# Altium schematic sheet lps_regulator_3.SchDoc
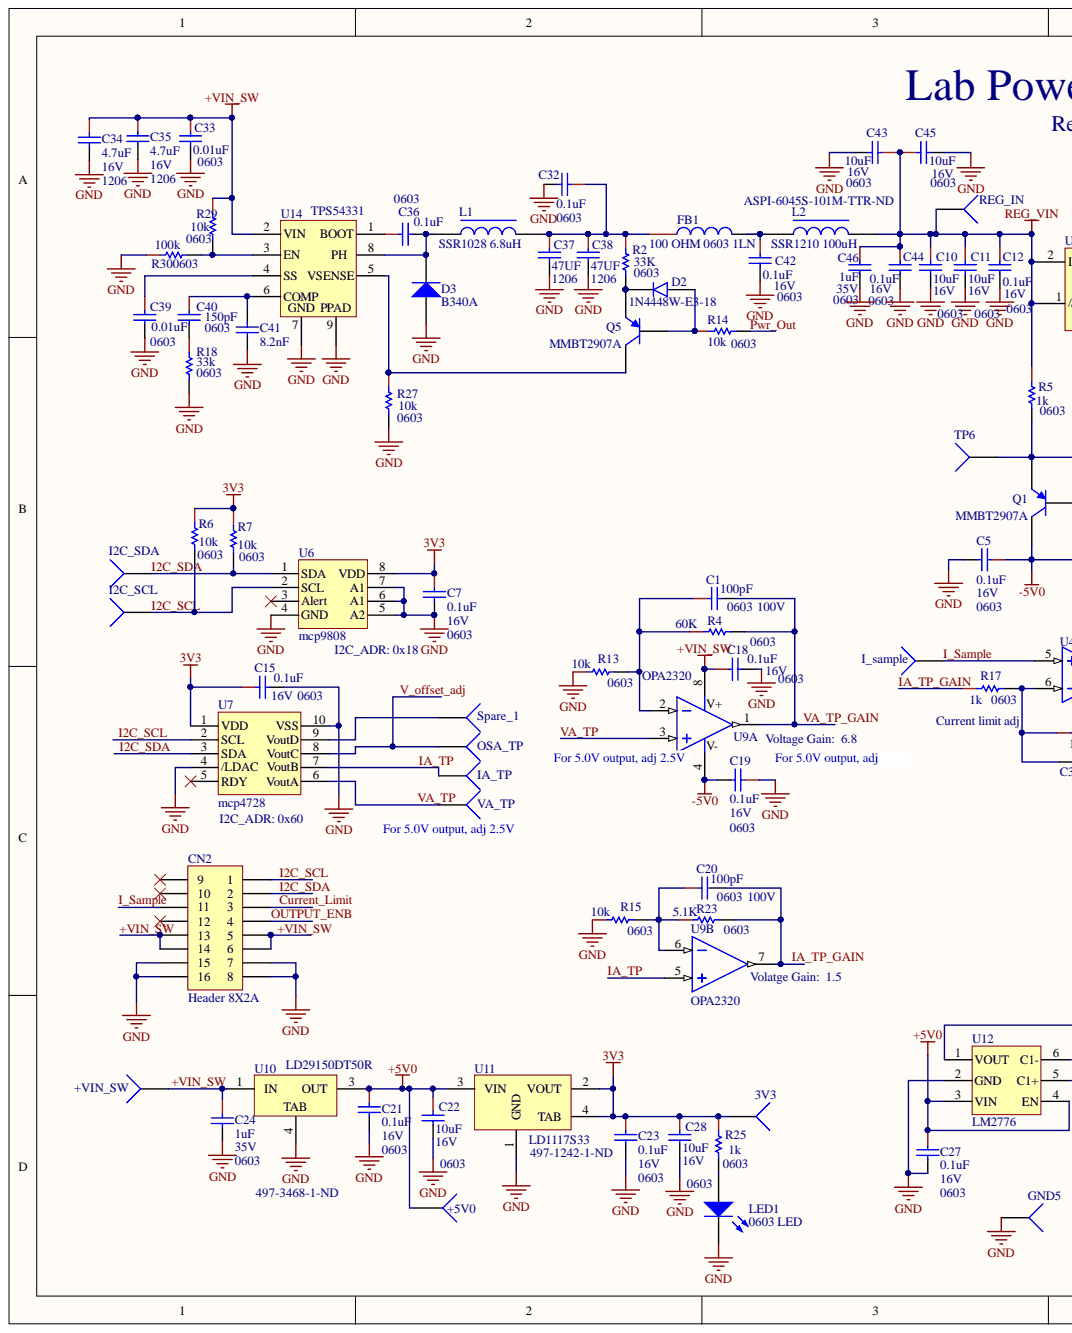
[diagram p0: lps_regulator_3.SchDoc, left]
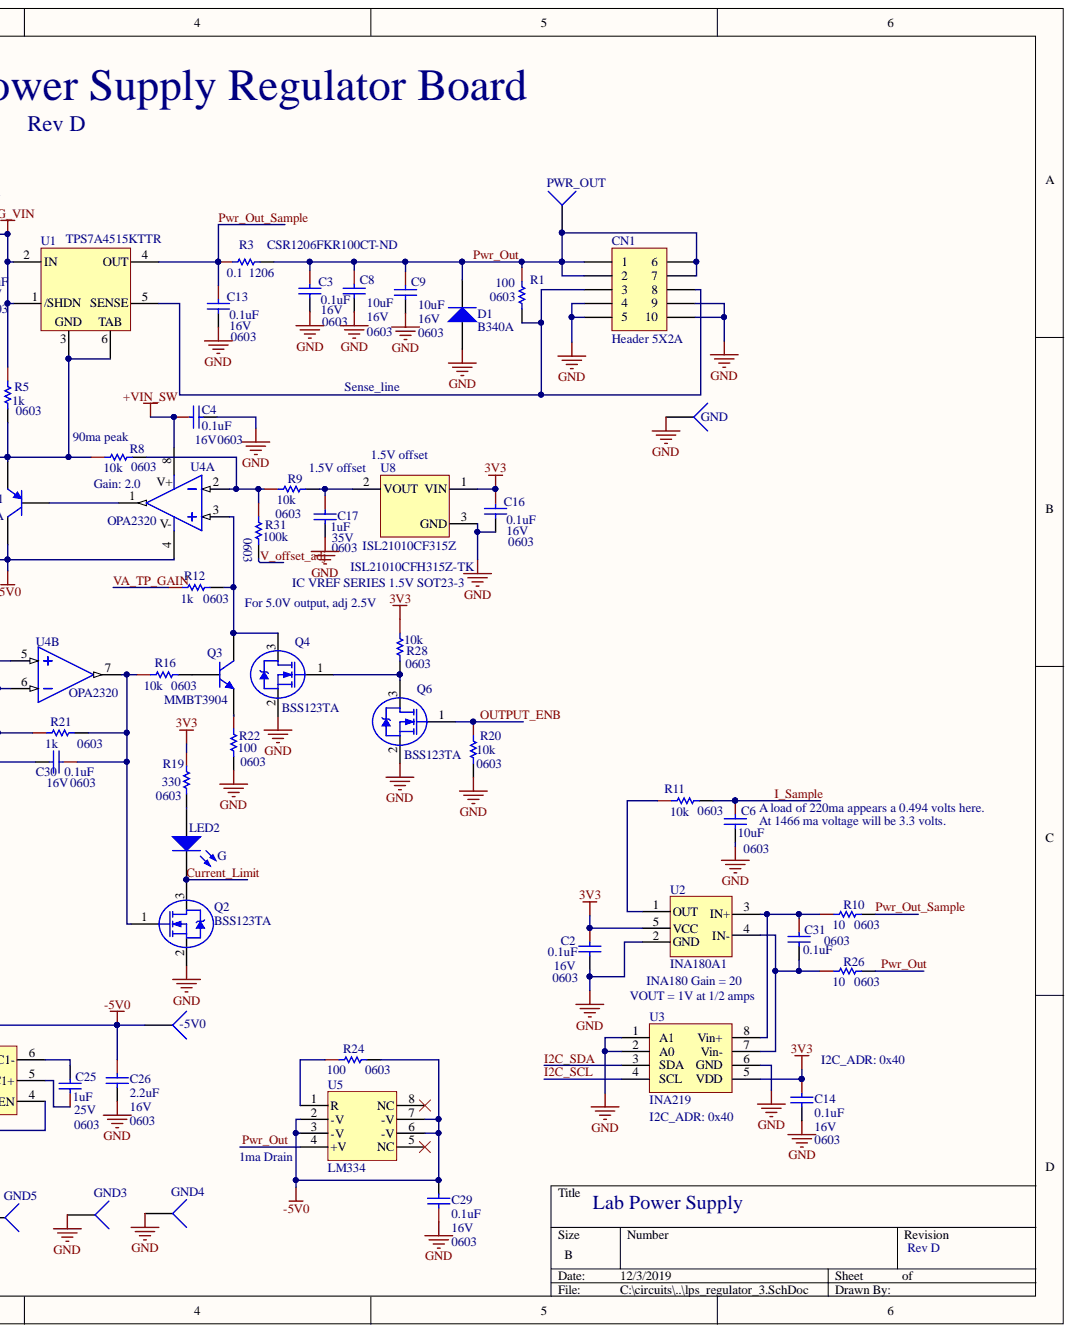
[diagram p1: lps_regulator_3.SchDoc, right]

## Parts
3V3  TestPoint_BLK  [TestPoint-TH 42 mil]  (value not drawn)
+5V0  TestPoint_BLK  [TestPoint-TH 42 mil]  (value not drawn)
+VIN_SW  TestPoint_BLK  [TestPoint-TH 42 mil]  (value not drawn)
-5V0  TestPoint_BLK  [TestPoint-TH 42 mil]  (value not drawn)
C1  100pF  Package (Imperial): 0603  Voltage (Rated): 100V  [CAPC0603(1608)_N]
C2  0.1uF  Package (Imperial): 0603  Voltage (Rated): 16V  [CAPC0603(1608)_M]
C3  0.1uF  Package (Imperial): 0603  Voltage (Rated): 16V  [CAPC0603(1608)_N]
C4  0.1uF  [CAPC0603(1608)_N]
C5  0.1uF  Package (Imperial): 0603  Voltage (Rated): 16V  [CAPC0603(1608)_N]
C6  10uF  Package (Imperial): 0603  [CAPC0603(1608)_N]
C7  0.1uF  Package (Imperial): 0603  Voltage (Rated): 16V  [CAPC0603(1608)_M]
C8  10uF  Package (Imperial): 0603  Voltage (Rated): 16V  [CAPC0603(1608)_N]
C9  10uF  Package (Imperial): 0603  Voltage (Rated): 16V  [CAPC0603(1608)_N]
C10  10uF  Package (Imperial): 0603  Voltage (Rated): 16V  [CAPC0603(1608)_N]
C11  10uF  Package (Imperial): 0603  Voltage (Rated): 16V  [CAPC0603(1608)_N]
C12  0.1uF  Package (Imperial): 0603  Voltage (Rated): 16V  [CAPC0603(1608)_N]
C13  0.1uF  Package (Imperial): 0603  Voltage (Rated): 16V  [CAPC0603(1608)_M]
C14  0.1uF  Package (Imperial): 0603  Voltage (Rated): 16V  [CAPC0603(1608)_N]
C15  0.1uF  Package (Imperial): 0603  Voltage (Rated): 16V  [CAPC0603(1608)_N]
C16  0.1uF  Package (Imperial): 0603  Voltage (Rated): 16V  [CAPC0603(1608)_N]
C17  1uF  Package (Imperial): 0603  Voltage (Rated): 35V  [CAPC0603(1608)_M]
C18  0.1uF  Package (Imperial): 0603  Voltage (Rated): 16V  [CAPC0603(1608)_M]
C19  0.1uF  Package (Imperial): 0603  Voltage (Rated): 16V  [CAPC0603(1608)_M]
C20  100pF  Package (Imperial): 0603  Voltage (Rated): 100V  [CAPC0603(1608)_N]
C21  0.1uF  Package (Imperial): 0603  Voltage (Rated): 16V  [CAPC0603(1608)_M]
C22  10uF  Package (Imperial): 0603  Voltage (Rated): 16V  [CAPC0603(1608)_N]
C23  0.1uF  Package (Imperial): 0603  Voltage (Rated): 16V  [CAPC0603(1608)_M]
C24  1uF  Package (Imperial): 0603  Voltage (Rated): 35V  [CAPC0603(1608)_M]
C25  1uF  Package (Imperial): 0603  Voltage (Rated): 25V  [CAPC0603(1608)_M]
C26  2.2uF  Package (Imperial): 0603  Voltage (Rated): 16V  [CAPC0603(1608)_M]
C27  0.1uF  Package (Imperial): 0603  Voltage (Rated): 16V  [CAPC0603(1608)_M]
C28  10uF  Package (Imperial): 0603  Voltage (Rated): 16V  [CAPC0603(1608)_N]
C29  0.1uF  Package (Imperial): 0603  Voltage (Rated): 16V  [CAPC0603(1608)_M]
C30  0.1uF  Package (Imperial): 0603  Voltage (Rated): 16V  [CAPC0603(1608)_M]
C31  0.1uF  Package (Imperial): 0603  [CAPC0603(1608)_N]
C32  0.1uF  [CAPC0603(1608)_N]
C33  0.01uF  Package (Imperial): 0603  [CAPC0603(1608)_N]
C34  4.7uF  Package (Imperial): 1206  Voltage (Rated): 16V  [CAPC1206(3216)_N]
C35  4.7uF  Package (Imperial): 1206  Voltage (Rated): 16V  [CAPC1206(3216)_N]
C36  0.1uF  Package (Imperial): 0603  [CAPC0603(1608)_N]
C37  47UF  Package (Imperial): 1206  [CAPC1206(3216)_L]
C38  47UF  Package (Imperial): 1206  [CAPC1206(3216)_L]
C39  0.01uF  Package (Imperial): 0603  [CAPC0603(1608)_N]
C40  150pF  Package (Imperial): 0603  [CAPC0603(1608)_M]
C41  8.2nF  Case/Package: 0603  [CAPC1608X87X35ML15T15]
C42  0.1uF  Package (Imperial): 0603  Voltage (Rated): 16V  [CAPC0603(1608)_N]
C43  10uF  Package (Imperial): 0603  Voltage (Rated): 16V  [CAPC0603(1608)_N]
C44  0.1uF  Package (Imperial): 0603  Voltage (Rated): 16V  [CAPC0603(1608)_N]
C45  10uF  Voltage (Rated): 16V  [CAPC0603(1608)_N]
C46  1uF  Package (Imperial): 0603  Voltage (Rated): 35V  [CAPC0603(1608)_M]
CN1  Header 5X2A  [HDR2X5_CEN]
CN2  Header 8X2A  [HDR2X8_CEN]
D1  B340A  [DO-214AC]
D2  1N4448W-E3-18  [SOD123]
D3  B340A  [DO-214AC]
FB1  100 OHM 0603 1LN  PkgCase: 0603  [0603-SMT]
GND  TestPoint_BLK  [TestPoint-TH 42 mil]  (value not drawn)
GND3  TestPoint_BLK  [TestPoint-TH 42 mil]  (value not drawn)
GND4  TestPoint_BLK  [TestPoint-TH 42 mil]  (value not drawn)
GND5  TestPoint_BLK  [TestPoint-TH 42 mil]  (value not drawn)
I2C_SCL  TestPoint_BLK  [TestPoint-TH 42 mil]  (value not drawn)
I2C_SDA  TestPoint_BLK  [TestPoint-TH 42 mil]  (value not drawn)
I_sample  TestPoint_BLK  [TestPoint-TH 42 mil]  (value not drawn)
IA_TP  TestPoint_BLK  [TestPoint-TH 42 mil]  (value not drawn)
L1  SSR1028 6.8uH  [SRU1028]
L2  SSR1210 100uH  [SRR1210]
LED1  0603 LED  [SM-LED-0603]
LED2  G  [SM-LED-0603]
OSA_TP  TestPoint_BLK  [TestPoint-TH 42 mil]  (value not drawn)
PWR_OUT  TestPoint_BLK  [TestPoint-TH 42 mil]  (value not drawn)
Q1  MMBT2907A  [SOT23]
Q2  BSS123TA  [SOT23-3L]
Q3  MMBT3904  [SOT23]
Q4  BSS123TA  [SOT23-3L]
Q5  MMBT2907A  [SOT23]
Q6  BSS123TA  [SOT23-3L]
R1  100  Package (Imperial): 0603  [RESC0603(1608)_N]
R2  Resistance: 33K  [RESC0603(1608)_M]  (hidden comment: 10K)
R3  Package (Imperial): 1206  Resistance: 0.1  [RESC1206(3216)_N]  (hidden comment: 0.33)
R4  100  Package (Imperial): 0603  Resistance: 60K  [RESC0603(1608)_N]
R5  Package (Imperial): 0603  Resistance: 1k  [RESC0603(1608)_M]  (hidden comment: 10K)
R6  Package (Imperial): 0603  [RESC0603(1608)_M]  (hidden comment: 10K)
R7  Package (Imperial): 0603  [RESC0603(1608)_M]  (hidden comment: 10K)
R8  Package (Imperial): 0603  [RESC0603(1608)_M]  (hidden comment: 10K)
R9  10K  [RESC0603(1608)_M]
R10  Package (Imperial): 0603  [RESC0603(1608)_M]  (hidden comment: 10K)
R11  Package (Imperial): 0603  [RESC0603(1608)_M]  (hidden comment: 10K)
R12  Package (Imperial): 0603  Resistance: 1k  [RESC0603(1608)_M]  (hidden comment: 1.0K)
R13  Package (Imperial): 0603  Resistance: 10k  [RESC0603(1608)_M]  (hidden comment: 1.0K)
R14  Package (Imperial): 0603  [RESC0603(1608)_M]  (hidden comment: 10K)
R15  Package (Imperial): 0603  Resistance: 10k  [RESC0603(1608)_M]  (hidden comment: 1.0K)
R16  Package (Imperial): 0603  Resistance: 10k  [RESC0603(1608)_M]  (hidden comment: 1.0K)
R17  Package (Imperial): 0603  Resistance: 1k  [RESC0603(1608)_M]  (hidden comment: 1.0K)
R18  Package (Imperial): 0603  [RESC0603(1608)_N]  (hidden comment: 33K)
R19  Package (Imperial): 0603  [RESC0603(1608)_M]  (hidden comment: 1.0K)
R20  Package (Imperial): 0603  [RESC0603(1608)_M]  (hidden comment: 10K)
R21  Package (Imperial): 0603  Resistance: 1k  [RESC0603(1608)_M]  (hidden comment: 100K)
R22  Package (Imperial): 0603  [RESC0603(1608)_M]  (hidden comment: 1.0K)
R23  100  Package (Imperial): 0603  [RESC0603(1608)_N]
R24  100  Package (Imperial): 0603  [RESC0603(1608)_N]
R25  Package (Imperial): 0603  Resistance: 1k  [RESC0603(1608)_M]  (hidden comment: 1.0K)
R26  Package (Imperial): 0603  [RESC0603(1608)_M]  (hidden comment: 10K)
R27  Package (Imperial): 0603  [RESC0603(1608)_M]  (hidden comment: 10K)
R28  Package (Imperial): 0603  [RESC0603(1608)_M]  (hidden comment: 10K)
R29  Package (Imperial): 0603  [RESC0603(1608)_M]  (hidden comment: 10K)
R30  Package (Imperial): 0603  Resistance: 100k  [RESC0603(1608)_M]  (hidden comment: 10K)
R31  Package (Imperial): 0603  Resistance: 100k  [RESC0603(1608)_M]  (hidden comment: 1.0K)
REG_IN  TestPoint_BLK  [TestPoint-TH 42 mil]  (value not drawn)
Spare_1  TestPoint_BLK  [TestPoint-TH 42 mil]  (value not drawn)
TP6  TestPoint_BLK  [TestPoint-TH 42 mil]  (value not drawn)
U1  TPS7A4515KTTR  [TPS7A4515KTTR]
U2  INA180A1  [SOT-23-5_MC]
U3  INA219  [INA219]
U4  OPA2320  [SOIC-8_NoPad]  (parts A,B)
U5  LM334  [LM334]
U6  mcp9808  [8MSOP]
U7  mcp4728  [MCP4728]
U8  ISL21010CF315Z  [SOT23]
U9  OPA2320  [SOIC-8_NoPad]  (parts A,B)
U10  LD29150DT50R  [TO-252_N]
U11  LD1117S33  [DSO-G3]
U12  LM2776  [SOt-23-6]
U14  TPS54331  [TPS54331]
VA_TP  TestPoint_BLK  [TestPoint-TH 42 mil]  (value not drawn)

## Nets
3V3: 3V3.1 C2.1 C7.1 C14.1 C15.1 C16.1 C23.1 C28.1 R6.1 R7.1 R19.1 R25.1 R28.1 U2.5(VCC) U3.5(VDD) U6.8(VDD) U7.1(VDD) U8.1 U11.2(VOUT) U11.4(TAB)
+5V0: +5V0.1 C21.1 C22.1 C27.1 U10.3 U11.3(VIN) U12.3(VIN) U12.4(EN)
-5V0: -5V0.1 C5.1 C19.2 C26.1 C29.2 Q1.3 R24.2 U4.4 U5.2(-V) U5.3(-V) U5.6(-V) U5.7(-V) U9.4 U12.1(VOUT)
GND: C2.2 C3.2 C4.2 C5.2 C6.2 C7.2 C8.2 C9.2 C10.2 C11.2 C12.2 C13.2 C14.2 C15.2 C16.2 C17.2 C18.2 C19.1 C21.2 C22.2 C23.2 C24.2 C26.2 C27.2 C28.2 C29.1 C32.2 C33.2 C34.2 C35.2 C37.2 C38.2 C39.2 C41.1 C42.2 C43.2 C44.2 C45.2 C46.2 CN1.4 CN1.5 CN1.9 CN1.10 CN2.7 CN2.8 CN2.15 CN2.16 D1.1 D3.1 GND.1 GND3.1 GND4.1 GND5.1 LED1.2 Q2.2 Q4.2 Q6.2 R13.1 R15.1 R18.2 R20.2 R22.2 R27.2 R30.1 U2.2(GND) U3.1(A1) U3.2(A0) U3.6(GND) U6.4(GND) U6.5(A2) U6.6(A1) U6.7(A1) U7.4(/LDAC) U7.10(VSS) U8.3 U10.4 U11.1(GND) U12.2(GND) U14.7 U14.9
REG_VIN: C10.1 C11.1 C12.1 C43.1 C44.1 C45.1 C46.1 L2.2 R5.1 REG_IN.1 U1.1(/SHDN) U1.2(IN)
+VIN_SW: +VIN_SW.1 C4.1 C18.1 C24.1 C33.1 C34.1 C35.1 CN2.5 CN2.6 CN2.13 CN2.14 R29.1 U4.8 U9.8 U10.1 U14.2(VIN)
Current_Limit: CN2.3 LED2.2 Q2.3
I2C_SCL: CN2.1 I2C_SCL.1 R6.2 U3.4(SCL) U6.2(SCL) U7.2(SCL)
I2C_SDA: CN2.2 I2C_SDA.1 R7.2 U3.3(SDA) U6.1(SDA) U7.3(SDA)
I_Sample: C6.1 CN2.11 I_sample.1 R11.2 U4.5
IA_TP: IA_TP.1 U7.7(VoutB) U9.5
IA_TP_GAIN: C20.2 R17.1 R23.2 U9.7
OUTPUT_ENB: CN2.4 Q6.1 R20.1
Pwr_Out: C3.1 C8.1 C9.1 CN1.1 CN1.2 CN1.6 CN1.7 D1.2 PWR_OUT.1 R1.1 R3.2 R14.2 R26.2 U5.4(+V)
Pwr_Out_Sample: C13.1 R3.1 R10.2 U1.4(OUT)
V_offset_adj: OSA_TP.1 R31.2 U7.8(VoutC)
VA_TP: U7.6(VoutA) U9.3 VA_TP.1
VA_TP_GAIN: C1.2 R4.2 R12.1 U9.1
NetC1_1: C1.1 R4.1 R13.2 U9.2
NetC17_1: C17.1 R9.2 U8.2
NetC20_1: C20.1 R15.2 R23.1 U9.6
NetC25_1: C25.1 U12.5(C1+)
NetC25_2: C25.2 U12.6(C1-)
NetC30_1: C30.1 Q2.1 R16.1 R21.2 U4.7
NetC30_2: C30.2 R17.2 R21.1 U4.6
NetC31_1: C31.1 R10.1 U2.3(IN+) U3.8(Vin+)
NetC31_2: C31.2 R26.1 U2.4(IN-) U3.7(Vin-)
NetC32_1: C32.1 C37.1 C38.1 FB1.1 L1.2 R2.1
NetC36_1: C36.1 U14.1(BOOT)
NetC36_2: C36.2 D3.2 L1.1 U14.8(PH)
NetC39_1: C39.1 U14.4(SS)
NetC40_1: C40.1 C41.2 U14.6(COMP)
NetC40_2: C40.2 R18.1
NetC42_1: C42.1 FB1.2 L2.1
NetCN1_3: CN1.3 CN1.8 R1.2 U1.5(SENSE)
NetD2_1: D2.1 Q5.1 R14.1
NetD2_2: D2.2 Q5.2 R2.2
NetLED1_1: LED1.1 R25.2
NetLED2_1: LED2.1 R19.2
NetQ1_1: Q1.1 U4.1
NetQ1_2: Q1.2 R5.2 R8.1 TP6.1 U1.3 U1.6
NetQ3_1: Q3.1 R16.2
NetQ3_2: Q3.2 R22.1
NetQ3_3: Q3.3 Q4.3 R12.2 U4.3
NetQ4_1: Q4.1 Q6.3 R28.2
NetQ5_3: Q5.3 R27.1 U14.5(VSENSE)
NetR8_2: R8.2 R9.1 R31.1 U4.2
NetR11_1: R11.1 U2.1(OUT)
NetR24_1: R24.1 U5.1(R)
NetR29_2: R29.2 R30.2 U14.3(EN)
NetSpare_1_1: Spare_1.1 U7.9(VoutD)

Not connected: CN2 9 10 12; U5 5(NC) 8(NC); U6 3(Alert); U7 5(RDY)

## Library data of the parts
3V3 +5V0 +VIN_SW -5V0 GND GND3-GND5 I2C_SCL I2C_SDA I_sample IA_TP OSA_TP PWR_OUT REG_IN Spare_1 TP6 VA_TP = CMP-005-0210-01: Test Point - Black; Manufacturer: Keystone Electronics; Manufacturer Part Number: 5001; Color: Black; Component Kind: Standard; ComponentLink1Description: http://www.keyelco.com/product-pdf.cfm?p=1310; ComponentLink1URL: http://www.keyelco.com/product-pdf.cfm?p=1310; Component Name: TestPoint_BLK; Designator: TestPoint_BLK; Material: Base, Nylon 46, UL 94V-0; Mounting Type: 0.040" (1.02mm) Hole Diameter; Packaging: Bulk; Pin Count: 1; Pricing 1: 1=0.37, 10=0.316, 50=0.2298, 100=0.2209, 250=0.19832, 500=0.18932, 1000=0.1616, 2500=0.14424, 5000=0.13523 (USD); RoHS: RoHS Compliant; Stock 1: 335679; Terminal: 0.010" x 0.020" (0.25mm x 0.51mm) Phosphor Bronze Wire, Silver Plated; Type: PC Test Point, Miniature; Library Name: OLogic Nexus Vault
C1 C20 = CMP-007-0074-08: CAP CER 100PF 50V 5% NP0 0603; Dielectric Material: Ceramic; Package (Metric): 1608; Temperature Coefficient: C0G, NP0; Capacitance: 100pF; Component Kind: Standard; Mounting Type: SMD; Tolerance: 5%; Library Name: OLogic Nexus Vault
C2 C3 C5 C7 C12-C16 C18 C19 C21 C23 C27 C29-C31 C36 C42 C44 = CMP-007-0042-08: CAP CER 0.1UF 16V 10% X7R 0603; Capacitance: 100nF; Dielectric Material: Ceramic; Package (Metric): 1608; Component Kind: Standard; Mounting Type: SMD; Temperature Coefficient: X7R; Tolerance: 10%; Library Name: OLogic Nexus Vault
  C31 C36: Voltage (Rated): 16V
C4 C32 = CMP-007-0042-08: CAP CER 0.1UF 16V 10% X7R 0603; Capacitance: 100nF; Dielectric Material: Ceramic; Package (Imperial): 0603; Package (Metric): 1608; Component Kind: Standard; Mounting Type: SMD; Temperature Coefficient: X7R; Tolerance: 10%; Voltage (Rated): 16V; Library Name: OLogic Nexus Vault
C6 C8-C11 C22 C28 C43 = CMP-001-0603-12: CAP CER 10UF 16V X5R 0603; Capacitance: 10µF; Dielectric Material: Ceramic; Package (Metric): 1005; Temperature (Max): 85°C; Temperature (Min): -55°C; Tolerance: 10%; Component Kind: Standard; Height: 800µm; Mounting Type: SMD; Temperature Coefficient: X5R; Width: 800µm; Library Name: OLogic Nexus Vault
  C6: Voltage (Rated): 16V
C17 C24 C40 C46 = CMP-007-0109-08: CAP CER 1UF 35V 10% X5R 0603; Capacitance: 1µF; Dielectric Material: Ceramic; Package (Metric): 1608; Temperature (Max): 85°C; Temperature (Min): -55°C; Component Kind: Standard; Height: 900µm; Length: 1.6mm; Mounting Type: SMD; Temperature Coefficient: X5R; Tolerance: 10%; Width: 800µm; Library Name: OLogic Nexus Vault
  C40: Voltage (Rated): 35V
C25 = CMP-007-0108-08: CAP CER 1UF 25V 10% X7R 0603; Capacitance: 1µF; Dielectric Material: Ceramic; Package (Metric): 1608; Temperature (Max): 125°C; Temperature (Min): -55°C; Component Kind: Standard; Height: 900µm; Length: 1.6mm; Mounting Type: SMD; Temperature Coefficient: X7R; Tolerance: 10%; Width: 800µm; Library Name: OLogic Nexus Vault
C26 = CMP-007-0114-08: CAP CER 2.2UF 16V 10% X5R 0603; Capacitance: 2.2µF; Dielectric Material: Ceramic; Package (Metric): 1608; Component Kind: Standard; Mounting Type: SMD; Temperature Coefficient: X5R; Tolerance: 10%; Library Name: OLogic Nexus Vault
C33 = CMP-007-0028-08: CAP CER 0.01uF 50V 10% X7R 0603; Capacitance: 10nF; Dielectric Material: Ceramic; Package (Metric): 1608; Temperature (Max): 125°C; Temperature (Min): -55°C; Component Kind: Standard; Height: 900µm; Length: 1.6mm; Mounting Type: SMD; Temperature Coefficient: X7R; Tolerance: 10%; Voltage (Rated): 50V; Width: 800µm; Library Name: OLogic Nexus Vault
C34 C35 = CMP-007-0147-08: CAP CER 4.7UF 50V 10% X7R 1206; Capacitance: 4.7µF; Dielectric Material: Ceramic; Package (Metric): 3216; Component Kind: Standard; Mounting Type: SMD; Temperature Coefficient: X7R; Tolerance: 10%; Library Name: OLogic Nexus Vault
C37 C38 = CMP-001-0623-11: CAP CER 47UF 25V 1206; Capacitance: 47µF; Dielectric Material: Ceramic; Package (Metric): 3216; Temperature (Max): 85°C; Temperature (Min): -55°C; Temperature Coefficient: X5R; Tolerance: 20%; Voltage (Rated): 100V; Component Kind: Standard; Height: 1.6mm; Length: 3.2mm; Mounting Type: SMD; Library Name: OLogic Nexus Vault
C39 = CMP-007-0128-08: CAP CER 22PF 50V 5% NP0 0603; Dielectric Material: Ceramic; Package (Metric): 1608; Temperature Coefficient: C0G, NP0; Capacitance: 22pF; Component Kind: Standard; Mounting Type: SMD; Tolerance: 5%; Voltage (Rated): 50V; Library Name: OLogic Nexus Vault
C41 = CMP-001-0751-01: CAP CER 820PF 50V X7R 0603; Dielectric Material: Ceramic; Dissipation Factor: 2.5%; Tolerance: 10%; Capacitance: 820pF; Case Code (Imperial): 0603; Case Code (Metric): 1608; Component Kind: Standard; Contact Plating: Matte, Tin; Depth: 0.8mm; Dielectric: X7R; Height: 0.8mm; Lead Pitch: 0.7mm; Length: 1.6mm; Max Operating Temperature: 125°C; Min Operating Temperature: -55°C; Mount: PCB, Surface Mount; Package Quantity: 1; Packaging: Tape and Reel; Radiation Hardening: No; REACH SVHC: No SVHC; Termination: SMD/SMT; Thickness: 0.034inch; Voltage: 50V; Voltage Rating: 50V; Voltage Rating (DC): 50V; Width: 0.8mm; Library Name: OLogic Nexus Vault
C45 = CMP-001-0603-12: CAP CER 10UF 16V X5R 0603; Capacitance: 10µF; Dielectric Material: Ceramic; Package (Imperial): 0603; Package (Metric): 1005; Temperature (Max): 85°C; Temperature (Min): -55°C; Tolerance: 10%; Component Kind: Standard; Height: 800µm; Mounting Type: SMD; Temperature Coefficient: X5R; Width: 800µm; Library Name: OLogic Nexus Vault
CN1 = CMP-001-0310-01: Header, 5-Pin, Dual row; Component Kind: Standard; Component Name: Header 5X2A; Designator: Header 5X2A; LatestRevisionDate: 17-Jul-2002; LatestRevisionNote: Re-released for DXP Platform.; Pin Count: 10; Signal Integrity: Connector; Library Name: OLogic Nexus Vault; Publisher: Altium Limited
CN2 = CMP-001-0325-01: Header, 8-Pin, Dual row; Component Kind: Standard; Component Name: Header 8X2A; Designator: Header 8X2A; LatestRevisionDate: 17-Jul-2002; LatestRevisionNote: Re-released for DXP Platform.; Pin Count: 16; Signal Integrity: Connector; Library Name: OLogic Nexus Vault; Publisher: Altium Limited
D1 D3 = CMP-001-0130-02: DIODE SCHOTTKY 60V 3A; Manufacturer: Micro Commercial Co; Capacitance @ Vr, F: 250pF @ 4V, 1MHz; Component Name: SK36A-LTP; Current - Reverse Leakage @ Vr: 500µA @ 60V; Speed: Fast Recovery =< 500ns, > 200mA (Io); Voltage - Forward (Vf) (Max) @ If: 750mV @ 3A; Component Kind: Standard; ComponentLink1Description: http://www.mccsemi.com/up_pdf/SK32A-LT~SK310A-LT(DO-214AC).PDF; ComponentLink1URL: http://www.mccsemi.com/up_pdf/SK32A-LT~SK310A-LT(DO-214AC).PDF; Current - Average Rectified (Io): 3A; Designator: SK36A-LTP; Diode Type: Schottky; Manufacturer Part Number: SK36A-LTP; Mounting Type: Surface Mount; Operating Temperature - Junction: -50°C ~ 125°C; Package / Case: DO-214AC, SMA; Packaging: Cut Tape (CT); Part Status: Active; Pin Count: 2; Pricing 1: 1=0.6, 10=0.42, 25=0.3448, 100=0.276, 250=0.20072, 500=0.1631, 1000=0.12545, 2500=0.11291 (USD); RoHS: RoHS Compliant; Stock 1: 26020; Supplier Device Package: DO-214AC (SMA); Library Name: OLogic Nexus Vault
D2 = CMP-001-0983-02: Diodes - General Purpose, Power, Switching 100 Volt 500mA 4ns; Average Rectified Current: 150mA; Element Configuration: Single; Max Power Dissipation: 500mW; Max Repetitive Reverse Voltage (Vrrm): 100V; Max Reverse Leakage Current: 5uA; Max Reverse Voltage (DC): 75V; Component Kind: Standard; Contact Plating: Tin; Forward Current: 150mA; Max Operating Temperature: 150°C; Max Surge Current: 500mA; Min Operating Temperature: -55°C; Mount: Surface Mount; Package Quantity: 10000; Packaging: Tape and Reel; Peak Non-Repetitive Surge Current: 500mA; Recovery Time: 4ns; Reverse Recovery Time: 4ns; Weight: 0.000363oz; Library Name: OLogic Nexus Vault
FB1 = CMP-026-0038-02: 100 Ohms @ 100MHz 1 Power Line Ferrite Bead 0603 (1608 Metric) 3A 30mOhm; ICSPartNumber: 600-0180; Manufacturer: TDK; Manufacturer Part Number: MPZ1608S101A; Component Name: FERRITE_BEAD_A; LifeStatus: CUR; MntType: SMT; OpTemp: -55C ~ 125C; value: 100R@100M; Component Kind: Standard; Current: 3A; DateCreated: 5/27/2013 5:21:44 PM; DateModified: 10/30/2014 12:43:40 AM; Designator: L; Features: 30 mOhm Max; HelpURL: http://www.tdk.co.jp/tefe02/e9413_mpz.pdf; ICS_PartType: ferrite; IPCCode: INDC1608X75-2; PartID: IND-000082; PartType: ferrite; Pin Count: 2; Revision: REV; RoHS: ROHS; Library Name: OLogic Nexus Vault
L1 = CMP-001-0686-01: INDUCTOR 6.8uH  SMD; Manufacturer 1: Taiyo Yuden; Manufacturer Part Number 1: NRS8040T4R7NJGJV; Core Material: Ferrite; Current Rating: 4.1A; DC Resistance (DCR): 18mR; Inductance: 4.7uh; Inductance Tolerance: 30%; Max DC Current: 5.4A; Self Resonant Frequency: 30MHz; Shielding: Shielded; Test Frequency: 100kHz; Case/Package: 8040; Component Kind: Standard; Depth: 8.2mm; Halogen Free: Halogen Free; Height: 4.2mm; Lead Free: Lead Free; Lead Pitch: 5.6mm; Length: 8mm; Max Operating Temperature: 125°C; Min Operating Temperature: -25°C; Mount: Surface Mount; Number of Pins: 2; Package Quantity: 1000; Packaging: Tape and Reel; Radiation Hardening: No; REACH SVHC: Unknown; RMS Current (Irms): 4.1A; Saturation Current: 5.4A; Termination: SMD/SMT; Tolerance: 30%; Width: 0.315inch; Library Name: OLogic Nexus Vault
L2 = CMP-001-0686-01: INDUCTOR 100uH 2.2A SMD; Manufacturer 1: Taiyo Yuden; Manufacturer Part Number 1: NRS8040T4R7NJGJV; Core Material: Ferrite; Current Rating: 4.1A; DC Resistance (DCR): 18mR; Inductance: 4.7uh; Inductance Tolerance: 30%; Max DC Current: 5.4A; Self Resonant Frequency: 30MHz; Shielding: Shielded; Test Frequency: 100kHz; Case/Package: 8040; Component Kind: Standard; Depth: 8.2mm; Halogen Free: Halogen Free; Height: 4.2mm; Lead Free: Lead Free; Lead Pitch: 5.6mm; Length: 8mm; Max Operating Temperature: 125°C; Min Operating Temperature: -25°C; Mount: Surface Mount; Number of Pins: 2; Package Quantity: 1000; Packaging: Tape and Reel; Radiation Hardening: No; REACH SVHC: Unknown; RMS Current (Irms): 4.1A; Saturation Current: 5.4A; Termination: SMD/SMT; Tolerance: 30%; Width: 0.315inch; Library Name: OLogic Nexus Vault
LED1 = CMP-001-0009-04: 0603 SMD LED; Component Kind: Standard; Designator: 0603 LED; Pin Count: 2; Library Name: OLogic Nexus Vault
LED2 = 0603 LED: 0603 SMD LED; PN: P11500CT-ND; Vendor: Digikey; Component Kind: Standard; Library Name: OLogic-Diode-Opto-LED.IntLib
Q1 Q5 = CMP-012-0075-02: PNP General Purpose Amplifier; Manufacturer: Fairchild Semiconductor; Current - Collector (Ic) (Max): 800mA; DC Current Gain (hFE) (Min) @ Ic, Vce: 100 @ 150mA, 10V; Frequency - Transition: 200MHz; PN: MMBT2907AFSCT-ND; Power - Max: 350mW; Vce Saturation (Max) @ Ib, Ic: 1.6V @ 50mA, 500mA; Vendor: Digikey; Voltage - Collector Emitter Breakdown (Max): 60V; Component Kind: Standard; ComponentLink1Description: http://www.fairchildsemi.com/ds/MM/MMBT2907A.pdf; ComponentLink1URL: http://www.fairchildsemi.com/ds/MM/MMBT2907A.pdf; Component Name: MMBT2907A; Current - Collector Cutoff (Max): -; Designator: MMBT2907A; Manufacturer Part Number: MMBT2907A; Mounting Type: Surface Mount; MPN: MMBT2907A; Package / Case: TO-236-3, SC-59, SOT-23-3; Packaging: Cut Tape (CT); Pin Count: 3; Pricing 1: 1=0.2, 10=0.187, 25=0.17, 100=0.1224, 250=0.07208, 500=0.0598, 1000=0.04079 (USD); RoHS: RoHS Compliant; Stock 1: 74892; Supplier Device Package: SOT-23; Transistor Type: PNP; Library Name: OLogic Nexus Vault
Q2 Q4 Q6 = CMP-012-0021-01: MOSFET N-CH 100V 170MA SOT23-3; Manufacturer: Diodes Incorporated; Current - Continuous Drain (Id) @ 25°C: 170mA (Ta); Drive Voltage (Max Rds On,  Min Rds On): 4.5V, 10V; FET Type: N-Channel; Power Dissipation (Max): 360mW (Ta); Rds On (Max) @ Id, Vgs: 6 Ohm @ 100mA, 10V; Vgs (Max): ±20V; Vgs(th) (Max) @ Id: 2.8V @ 1mA; Component Kind: Standard; ComponentLink1Description: https://www.diodes.com/assets/Datasheets/BSS123.pdf; ComponentLink1URL: https://www.diodes.com/assets/Datasheets/BSS123.pdf; Component Name: BSS123TA; Designator: BSS123TA; FET Feature: -; Manufacturer Part Number: BSS123TA; Mounting Type: Surface Mount; Operating Temperature: -55°C ~ 150°C (TJ); Package / Case: TO-236-3, SC-59, SOT-23-3; Packaging: Cut Tape (CT); Part Status: Active; Pin Count: 3; Pricing 1: 1=0.59, 10=0.418, 100=0.2742, 500=0.16202, 1000=0.12463 (USD); RoHS: RoHS Compliant; Stock 1: 35338; Supplier Device Package: SOT-23-3; Technology: MOSFET (Metal Oxide); Library Name: OLogic Nexus Vault
Q3 = MMBT3904: NPN General Purpose Amplifier; Manufacturer: ON Semiconductor; Manufacturer Part Number: MMBT3904WT1G; Current - Collector (Ic) (Max): 200mA; DC Current Gain (hFE) (Min) @ Ic, Vce: 100 @ 10mA, 1V; Frequency - Transition: 300MHz; PN: MMBT3904WT1GOSCT-ND; Power - Max: 150mW; Vce Saturation (Max) @ Ib, Ic: 300mV @ 5mA, 50mA; Vendor: Digikey; Voltage - Collector Emitter Breakdown (Max): 40V; Category: Discrete Semiconductor Products; Component Kind: Standard; ComponentLink1Description: http://www.onsemi.com/pub_link/Collateral/MMBT3904WT1-D.PDF; ComponentLink1URL: http://www.onsemi.com/pub_link/Collateral/MMBT3904WT1-D.PDF; Current - Collector Cutoff (Max): -; Library Name: OLogic-Trans-Drivers.IntLib; Mounting Type: Surface Mount; MPN: MMBT3904WT1G; Package / Case: SC-70, SOT-323; Packaging: Cut Tape (CT); Pricing 1: 1=0.17, 10=0.154, 25=0.1404, 100=0.101, 250=0.05952, 500=0.04938, 1000=0.03368 (USD); RoHS: RoHS Compliant; Stock 1: 3; Supplier 1: Digi-Key; Supplier Device Package: SC-70-3 (SOT323); Supplier Part Number 1: MMBT3904WT1GOSCT-ND; Transistor Type: NPN
R1 R4 R24 = CMP-007-0349-12: RES 100 OHM 1% 1/10W 0603 2017; Package (Metric): 1608; Power: 100mW; Temperature Coefficient: ±100ppm/°C; Component Kind: Standard; Height: 550µm; Operating Temperature: -55°C ~ 155°C; Termination: SMD/SMT; Tolerance: 1%; Library Name: OLogic Nexus Vault
  R1 R24: Resistance: 100
R2 R9 = CMP-007-0359-12: RES 10K OHM 1/10W 1% 0603 SMD; Package (Imperial): 0603; Package (Metric): 1608; Power: 100mW; Temperature Coefficient: ±100ppm/°C; Component Kind: Standard; Height: 550µm; Termination: SMD/SMT; Tolerance: 1%; Library Name: OLogic Nexus Vault
  R9: Resistance: 10k
R3 = CMP-007-0323-14: RES 0.33 OHM 1% 1/4W 1206; Max Power Dissipation: 250mW; Temperature Coefficient: 200ppm/°C; Component Kind: Standard; Composition: Thick Film; Contact Plating: Tin; Depth: 1.6mm; Features: Automotive AEC-Q200; Height: 600µm; Length: 3.2mm; Max Operating Temperature: 125°C; Military Standard: Not; Min Operating Temperature: -55°C; Mount: Surface Mount; Number of Pins: 2; Number of Terminations: 2; Package (Metric): 1206; Package Quantity: 1; Packaging: Tape and Reel; Power: 250mW; Power Rating: 250mW; Radiation Hardening: No; Simulation: RESISTOR; Termination: SMD/SMT; Tolerance: 1%; Width: 1.6mm; Library Name: OLogic Nexus Vault
R5-R8 R11 R14 R20 R27-R30 = CMP-007-0359-12: RES 10K OHM 1/10W 1% 0603 SMD; Package (Metric): 1608; Power: 100mW; Temperature Coefficient: ±100ppm/°C; Component Kind: Standard; Height: 550µm; Termination: SMD/SMT; Tolerance: 1%; Library Name: OLogic Nexus Vault
  R6-R8 R11 R14 R20 R27-R29: Resistance: 10k
R10 R26 = CMP-007-0359-12: RES 10K OHM 1/10W 1% 0603 SMD; Package (Metric): 1608; Power: 100mW; Resistance: 10; Temperature Coefficient: ±100ppm/°C; Component Kind: Standard; Height: 550µm; Termination: SMD/SMT; Tolerance: 1%; Library Name: OLogic Nexus Vault
R12 R13 R15-R17 R25 R31 = CMP-007-0404-12: RES 1K OHM 1% 1/10W 0603; Package (Metric): 2012; Power: 100mW; Temperature Coefficient: ±100ppm/°C; Component Kind: Standard; Height: 550µm; Operating Temperature: -55°C ~ 155°C; Termination: SMD/SMT; Tolerance: 1%; Library Name: OLogic Nexus Vault
R18 = CMP-007-0480-12: RES 33K OHM 1/10W 5% 0603 SMD; Package (Metric): 1608; Power: 100mW; Temperature Coefficient: ±200ppm/°C; Component Kind: Standard; Height: 550µm; Resistance: 33k; Simulation: RESISTOR; Termination: SMD/SMT; Tolerance: 5%; Library Name: OLogic Nexus Vault
R19 = CMP-007-0404-12: RES 1K OHM 1% 1/10W 0603; Package (Metric): 2012; Power: 100mW; Resistance: 330; Temperature Coefficient: ±100ppm/°C; Component Kind: Standard; Height: 550µm; Operating Temperature: -55°C ~ 155°C; Termination: SMD/SMT; Tolerance: 1%; Library Name: OLogic Nexus Vault
R21 = CMP-007-0353-12: RES 100K OHM 1% 1/10W 0603; Package (Metric): 1608; Power: 100mW; Temperature Coefficient: ±100ppm/°C; Component Kind: Standard; Height: 550µm; Operating Temperature: -55°C ~ 155°C; Termination: SMD/SMT; Tolerance: 1%; Library Name: OLogic Nexus Vault
R22 = CMP-007-0404-12: RES 1K OHM 1% 1/10W 0603; Package (Metric): 2012; Power: 100mW; Resistance: 100; Temperature Coefficient: ±100ppm/°C; Component Kind: Standard; Height: 550µm; Operating Temperature: -55°C ~ 155°C; Termination: SMD/SMT; Tolerance: 1%; Library Name: OLogic Nexus Vault
R23 = CMP-007-0349-12: RES 100 OHM 1% 1/10W 0603 2017; Package (Metric): 1608; Power: 100mW; Resistance: 5.1K; Temperature Coefficient: ±100ppm/°C; Component Kind: Standard; Height: 550µm; Operating Temperature: -55°C ~ 155°C; Termination: SMD/SMT; Tolerance: 1%; Library Name: OLogic Nexus Vault
U1 = TPS7A4515KTTR: TPS7A4515KTTR, linear regulator; Component Kind: Standard; Library Name: hdmi_lvds.IntLib
U3 = INA219: Current Monitor IC; Component Kind: Standard; Library Name: hdmi_lvds.IntLib
U4 U9 = CMP-006-0027-01: Dual Single Supply Rail-to-Rail OpAmp; Manufacturer: Texas Instruments; Manufacturer Part Number: OPA2320AIDR; Amplifier Type: General Purpose; Current - Supply: 1.45mA; Slew Rate: 10 V/µs; Voltage - Supply, Single/Dual (±): 1.8 V ~ 5.5 V, ±0.9 V ~ 2.75 V; Base Part Number: OPA2320; Component Kind: Standard; ComponentLink1Description: http://www.ti.com/lit/ds/symlink/opa2320.pdf; ComponentLink1URL: http://www.ti.com/lit/ds/symlink/opa2320.pdf; Component Name: OPA2320; Designator: OPA2320; Mounting Type: Surface Mount; Operating Temperature: -40°C ~ 125°C; Output Type: Rail-to-Rail; Package / Case: 8-SOIC (0.154", 3.90mm Width); Packaging: Cut Tape (CT); Part Status: Active; Pin Count: 8; Pricing 1: 1=3, 10=2.697, 100=2.2094, 500=1.88078, 1000=1.5862 (USD); RoHS: RoHS Compliant; Source: OLogic-OpAmps.SCHLIB; Stock 1: 3660; Supplier Device Package: 8-SOIC; Library Name: OLogic Nexus Vault
U5 = LM334: LM334, Current source; Component Kind: Standard; Library Name: hdmi_lvds.IntLib
U10 = CMP-001-0685-01: STMICROELECTRONICS         LD29150DT33R            Fixed LDO Voltage Regulator, 4.3V to 30V, 400mV Dropout, 3.3Vout, 1.5Aout, TO-252-3; Accuracy: 1%; Input Bias Current: 15mA; Max Output Current: 1.5A; Max Output Voltage: 3.333V; Min Output Voltage: 3.3V; Number of Outputs: 1; Polarity: Positive; Reference Voltage: 1.2423V; Case/Package: TO-252; Component Kind: Standard; Contact Plating: Matte, Tin; Dropout Voltage: 400mV; Height: 2.4mm; Lead Free: Lead Free; Length: 6.6mm; Max Input Voltage: 30V; Max Operating Temperature: 125°C; Max Supply Voltage: 30V; Min Input Voltage: 4.3V; Min Operating Temperature: -40°C; Min Supply Voltage: 4.3V; Mount: Surface Mount; Nominal Output Voltage: 3.3V; Number of Pins: 3; Number of Regulators: 1; Output Current: 1.5A; Output Type: Fixed; Output Voltage: 3.3V; Output Voltage Accuracy: 1%; Package Quantity: 1; Packaging: Tape and Reel; Quiescent Current: 15mA; Radiation Hardening: No; REACH SVHC: No SVHC; Termination: SMD/SMT; Weight: 0.01lb; Width: 6.2mm; Library Name: OLogic Nexus Vault
U11 = CMP-008-0010-01: 3.3 volt fixed regulator, 800mA; Component Kind: Standard; Component Name: LD1117S33; Designator: LD1117S33; Pin Count: 4; Library Name: OLogic Nexus Vault
U2 = INA180A1: Component Kind: Standard; Library Name: hdmi_lvds.IntLib
U6 = mcp9808: Component Kind: Standard; Library Name: hdmi_lvds.IntLib
U7 = mcp4728: Component Kind: Standard; Description: MCP4728; Library Name: hdmi_lvds.IntLib
U8 = ISL21010CF315Z: Component Kind: Standard; Library Name: hdmi_lvds.IntLib
U12 = LM2776: Component Kind: Standard; Library Name: hdmi_lvds.IntLib
U14 = TPS54331: Component Kind: Standard; Description: TPS54331; Library Name: hdmi_lvds.IntLib
